# Altium schematic sheet OUTPUT RELAY.SchDoc("OUTPUT RELAY")
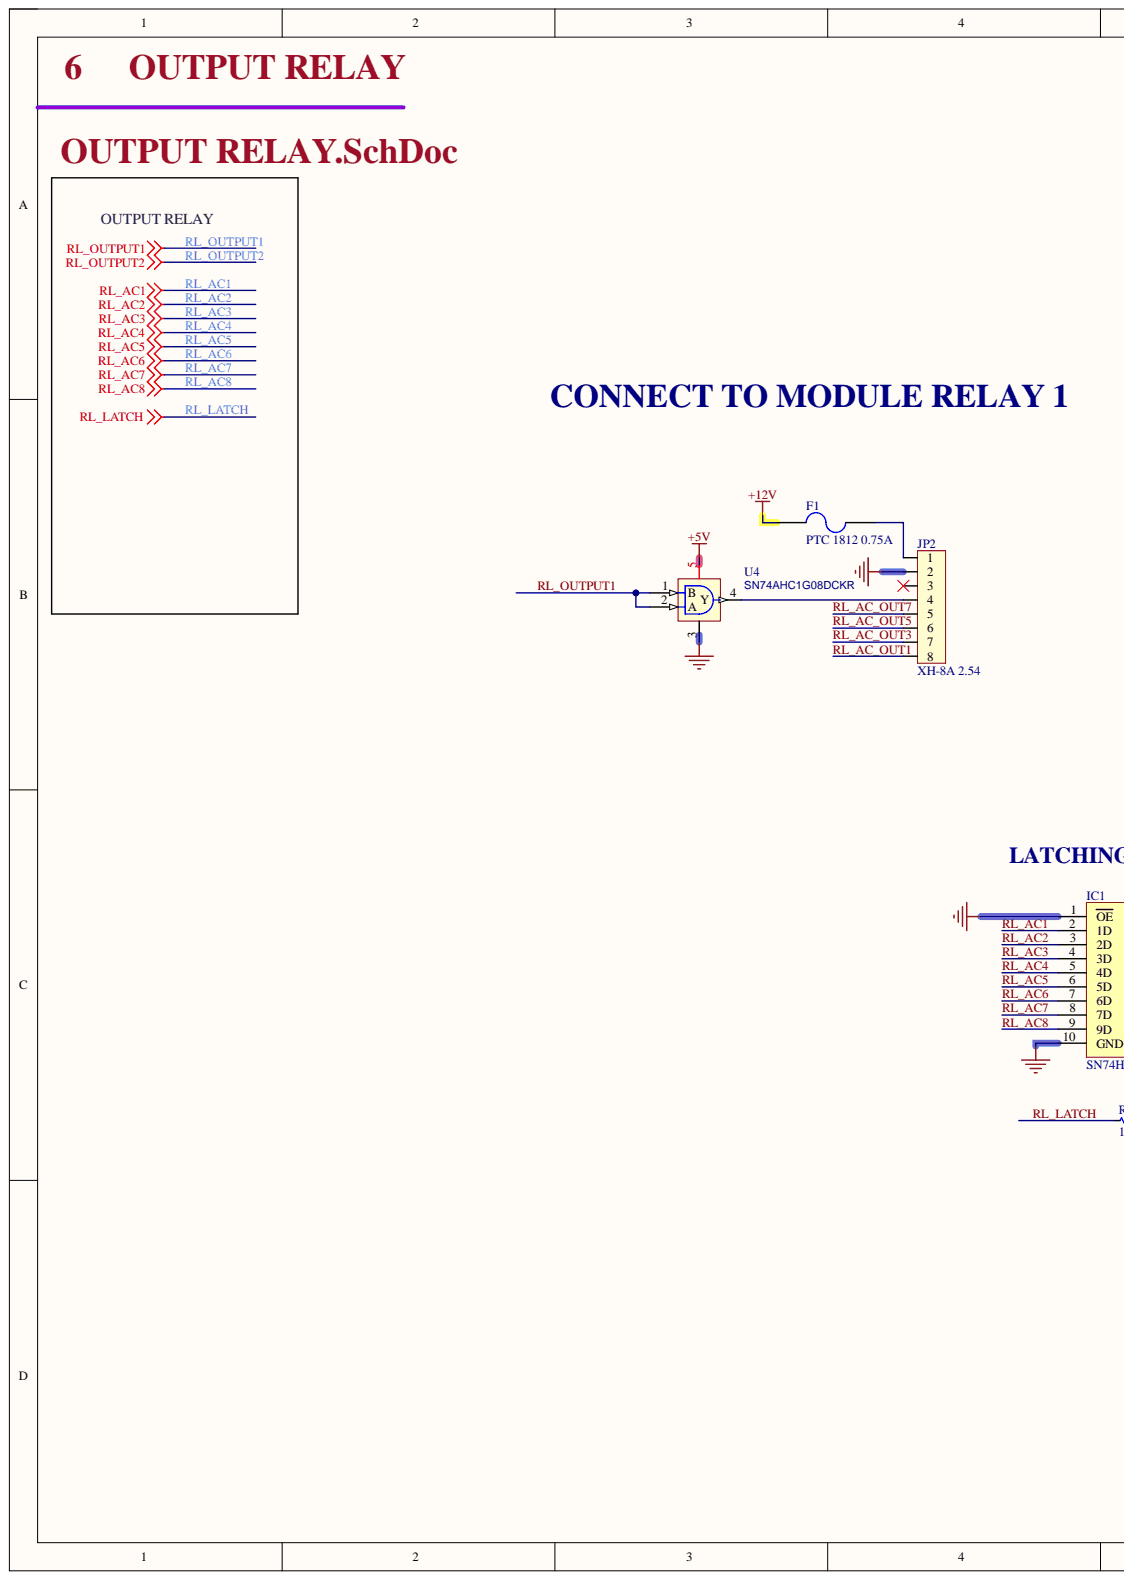
[diagram p0: OUTPUT RELAY.SchDoc, left]
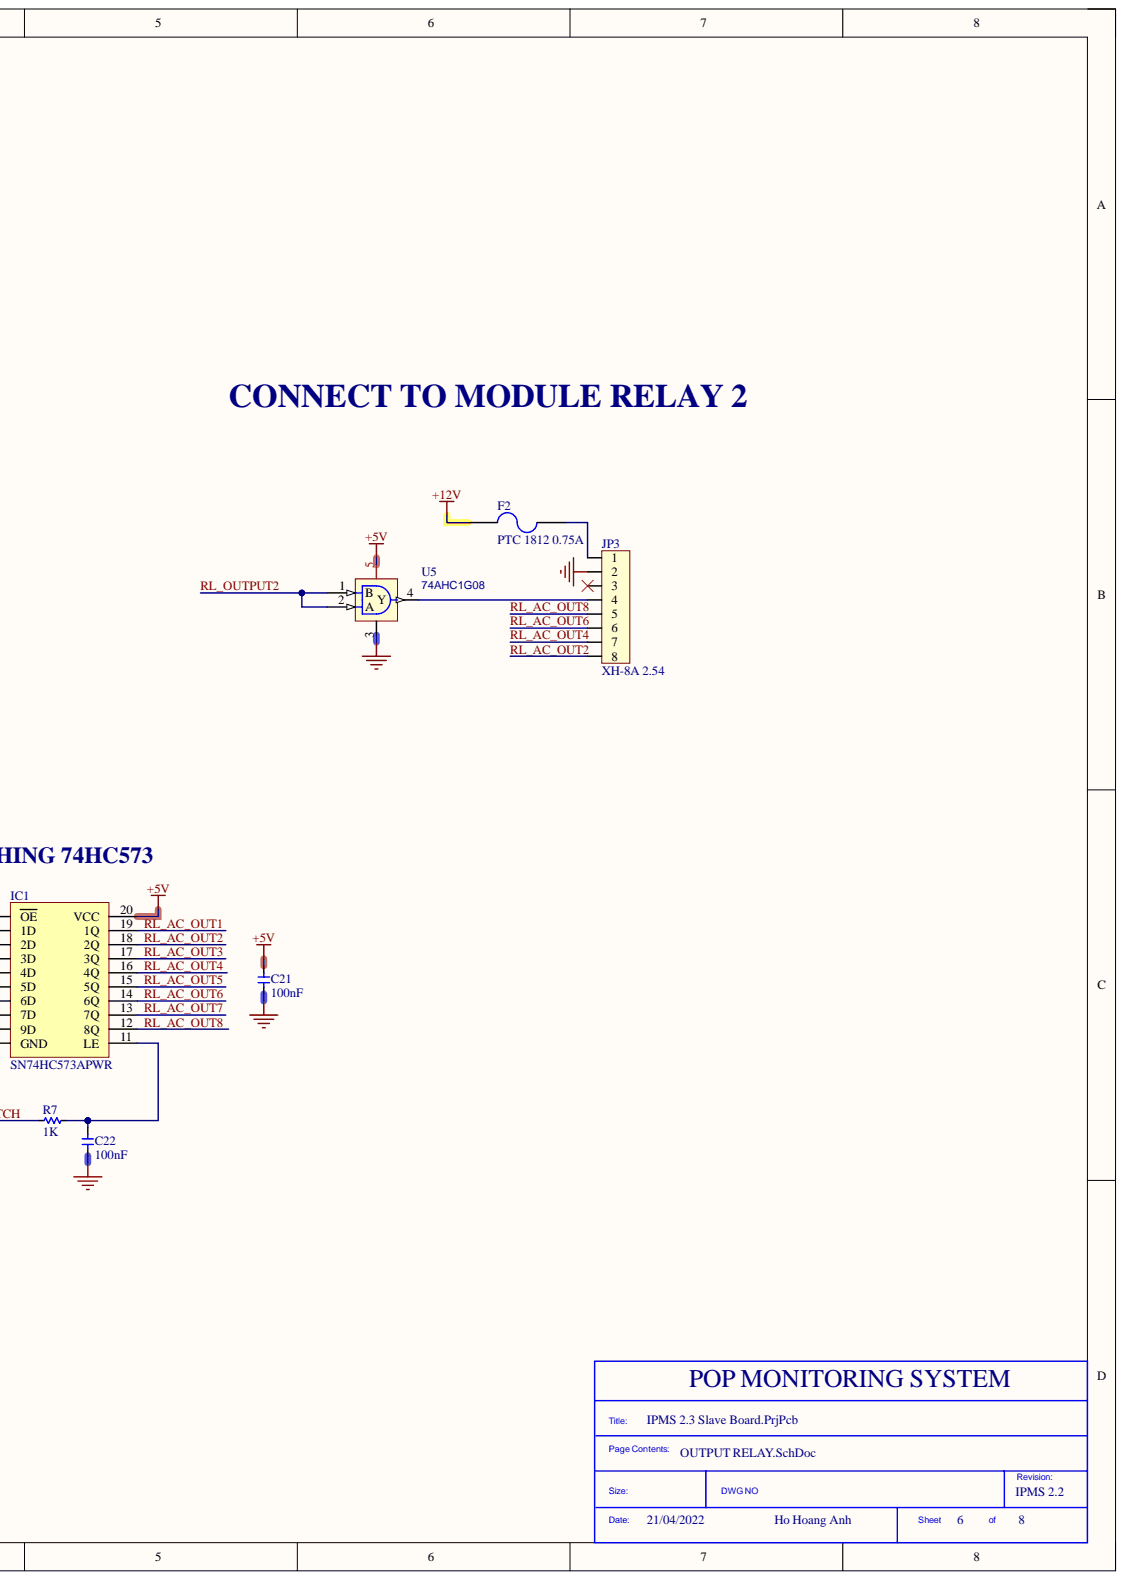
[diagram p1: OUTPUT RELAY.SchDoc, right]

## Parts
C21  100nF  [C_0603]
C22  100nF  [C_0603]
F1  PTC 1812 0.75A  Package: 1812  [F_1812]
F2  PTC 1812 0.75A  Package: 1812  [F_1812]
IC1  SN74HC573APWR  [TSSOP-20_L6.5-W4.4-P0.65-LS6.4-BL]
JP2  XH-8A 2.54  [XH-8A-2.54]
JP3  XH-8A 2.54  [XH-8A-2.54]
R7  1K  [R_0603]
U4  SN74AHC1G08DCKR  [SOT-353_L2.2-W1.4-P0.65-LS2.4-BR]
U5  74AHC1G08  [SOT-353_L2.2-W1.4-P0.65-LS2.4-BR]

## Nets
+5V: C21.1 IC1.20(VCC) U4.5 U5.5
+12V: F1.1 F2.1
GND: C21.2 C22.1 IC1.1(OE) IC1.10(GND) JP2.2 JP3.2 U4.3 U5.3
RL_AC1: IC1.2(1D)
RL_AC2: IC1.3(2D)
RL_AC3: IC1.4(3D)
RL_AC4: IC1.5(4D)
RL_AC5: IC1.6(5D)
RL_AC6: IC1.7(6D)
RL_AC7: IC1.8(7D)
RL_AC8: IC1.9(9D)
RL_AC_OUT1: IC1.19(1Q) JP2.8
RL_AC_OUT2: IC1.18(2Q) JP3.8
RL_AC_OUT3: IC1.17(3Q) JP2.7
RL_AC_OUT4: IC1.16(4Q) JP3.7
RL_AC_OUT5: IC1.15(5Q) JP2.6
RL_AC_OUT6: IC1.14(6Q) JP3.6
RL_AC_OUT7: IC1.13(7Q) JP2.5
RL_AC_OUT8: IC1.12(8Q) JP3.5
RL_LATCH: R7.1
RL_OUTPUT1: U4.1(B) U4.2(A)
RL_OUTPUT2: U5.1(B) U5.2(A)
NetC22_2: C22.2 IC1.11(LE) R7.2
NetF1_2: F1.2 JP2.1
NetF2_2: F2.2 JP3.1
NetJP2_4: JP2.4 U4.4(Y)
NetJP3_4: JP3.4 U5.4(Y)

Not connected: JP2 3; JP3 3

## Library data of the parts
C21 C22 = CC0603KRX7R9BB104: 100nF 50V X7R ±10% 0603 Multilayer Ceramic Capacitors MLCC - SMD/SMT ROHS; Manufacturer: YAGEO; Assembly Type: SMT Assembly; Component Kind: Standard; LCSC Part Number: C14663; Manufacturer Part Number: CC0603KRX7R9BB104; Package: 0603; Library Name: IPMS 2.3 Slave Board.SCHLIB
F1 F2 = PTC_mSMD075-33V: 1812 PTC RESETTABLE FUSES ROHS 24V; Manufacturer: TECHFUSE; Assembly Type: SMT Assembly; Component Kind: Standard; LCSC Part Number: C70117; Manufacturer Part Number: mSMD075-33V; Library Name: IPMS 2.3 Slave Board.SCHLIB
IC1 = SN74HC573APWR: IC OCT D-TYPE LATCH 20-SOIC; Manufacturer: Texas Instruments; Assembly Type: SMT Assembly; Component Kind: Standard; LCSC Part Number: C6759; Manufacturer Part Number: SN74HC573APWR; Package: TSSOP-20_4.4x6.5x0.65P; Library Name: IPMS 2.3 Slave Board.SCHLIB
JP2 JP3 = XH-8A 2.54: 1x8P, Brass Plugin,P=2.54mm Wire To Board / Wire To Wire Connector ROHS; Manufacturer: China; Manufacturer Part Number: XH-8A 2.54 Pitch=2.54; Assembly Type: Hand Soldering; Component Kind: Standard; LCSC Part Number: N/A; Package: Plugin, P=2.54; Library Name: IPMS 2.3 Slave Board.SCHLIB
R7 = 0603WAF1001T5E: 1KOHMS ±1% 1/10W 0603 ROHS; Manufacturer: Uniroyal Elec; Assembly Type: SMT Assembly; Component Kind: Standard; LCSC Part Number: C21190; Manufacturer Part Number: 0603WAF1001T5E; Package: 0603; Library Name: IPMS 2.3 Slave Board.SCHLIB
U4 U5 = SN74AHC1G08DCKR: 74 SERIES AND GATE 1 2V ~ 5.5V SOT-353 ROHS; Manufacturer: Texas Instruments; Assembly Type: SMT Assembly; Component Kind: Standard; LCSC Part Number: C122838; Manufacturer Part Number: SN74AHC1G08DCKR; Package: SOT-353; Library Name: IPMS 2.3 Slave Board.SCHLIB
